# Altium schematic sheet Main.SchDoc(Main)
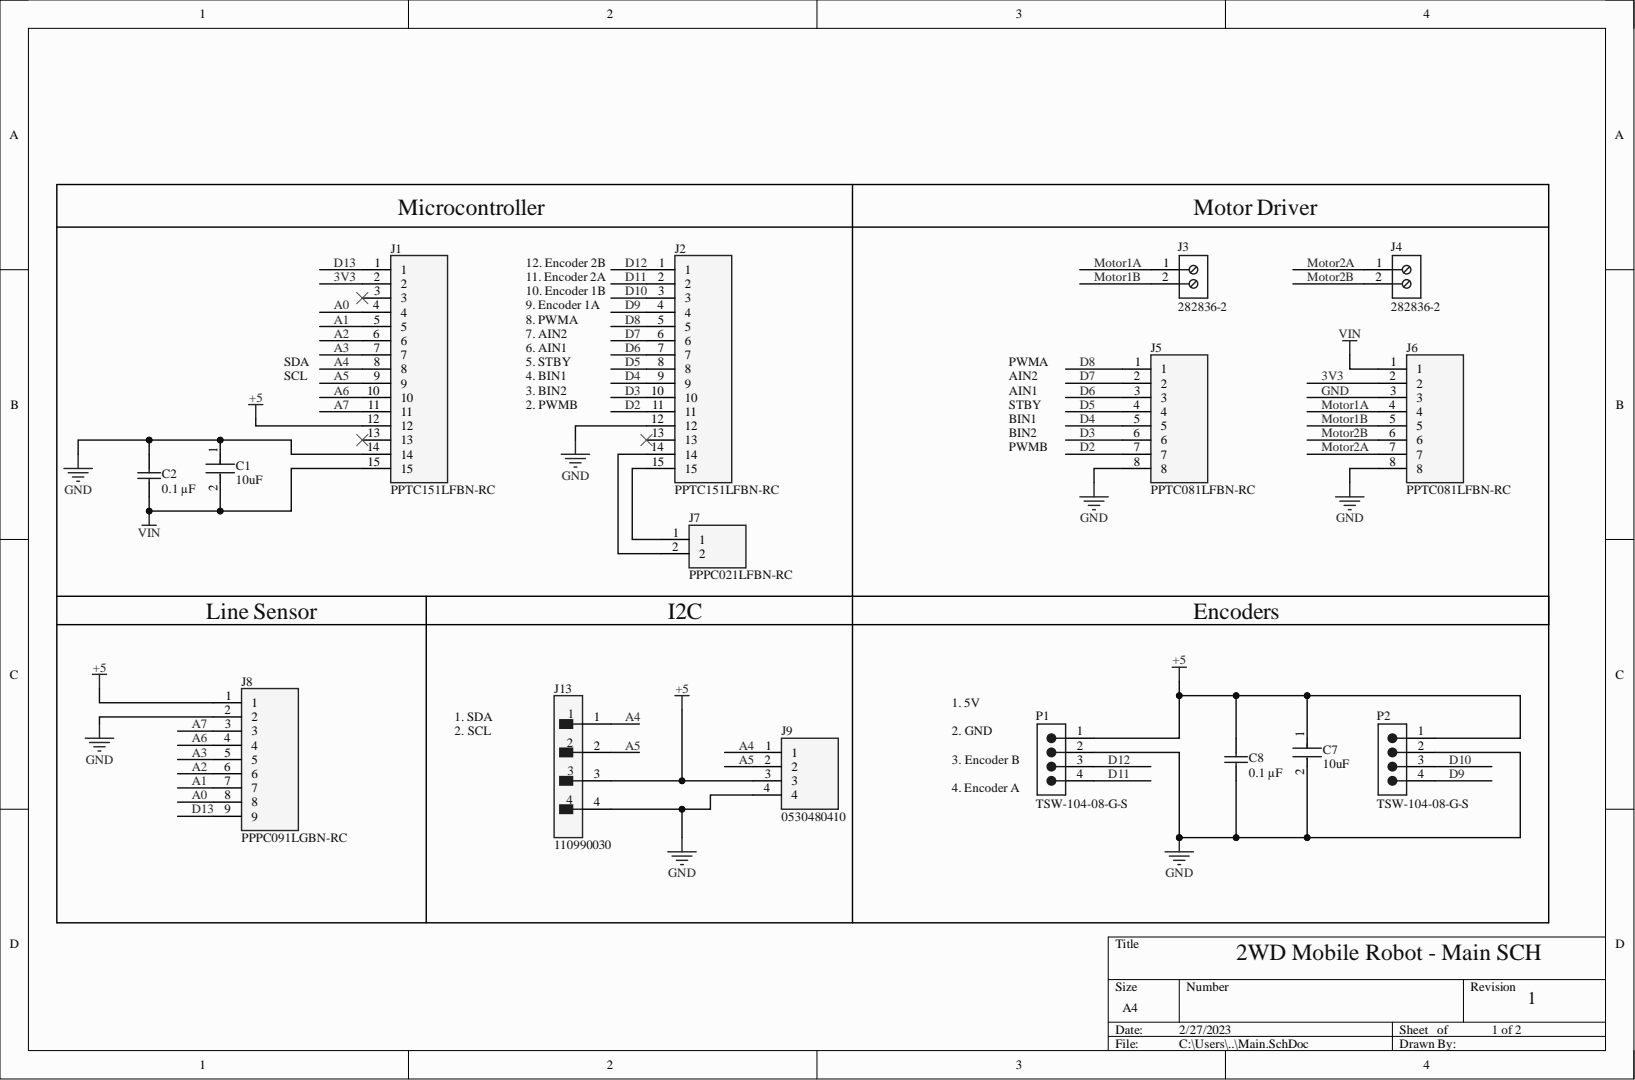
[diagram p0: Main.SchDoc]

## Parts
C1  10uF  [FP-GRM21B-0_2-e0_2_0_7-IPC_C]
C2  0.1 µF  [CAPC2013X140X50ML20T25]
C7  10uF  [FP-GRM21B-0_2-e0_2_0_7-IPC_C]
C8  0.1 µF  [CAPC2013X140X50ML20T25]
J1  PPTC151LFBN-RC  [RHDR15W85P0X254_1X15_3708X254X850P]
J2  PPTC151LFBN-RC  [RHDR15W85P0X254_1X15_3708X254X850P]
J3  282836-2  [TECO-282836-2_V]
J4  282836-2  [TECO-282836-2_V]
J5  PPTC081LFBN-RC  [RHDR8W80P0X254_1X8_2082X254X850P]
J6  PPTC081LFBN-RC  [RHDR8W80P0X254_1X8_2082X254X850P]
J7  PPPC021LFBN-RC  [RHDR2W80P0X254_1X2_558X254X850P]
J8  PPPC091LGBN-RC  [RHDRRA9W60P0X254_1X9_2336X850X274P]
J9  0530480410  [SHDRRA4W35P0X125_1X4_675X550X350P]
J13  110990030  [FP-110990030-MFG]
P1  TSW-104-08-G-S  [SMTC-TSW-104-08-X-S]
P2  TSW-104-08-G-S  [SMTC-TSW-104-08-X-S]

## Nets
+5: C7.1 C8.2 J1.12 J8.1 J9.3 J13.3 P1.1 P2.1
VIN: C1.2 C2.1 J1.15 J6.1
3V3: J1.2 J6.2
A0: J1.4 J8.8
A1: J1.5 J8.7
A2: J1.6 J8.6
A3: J1.7 J8.5
A4: J1.8 J9.1 J13.1
A5: J1.9 J9.2 J13.2
A6: J1.10 J8.4
A7: J1.11 J8.3
D2: J2.11 J5.7
D3: J2.10 J5.6
D4: J2.9 J5.5
D5: J2.8 J5.4
D6: J2.7 J5.3
D7: J2.6 J5.2
D8: J2.5 J5.1
D9: J2.4 P2.4
D10: J2.3 P2.3
D11: J2.2 P1.4
D12: J2.1 P1.3
D13: J1.1 J8.9
GND: C1.1 C2.2 C7.2 C8.1 J1.14 J2.12 J5.8 J6.3 J6.8 J8.2 J9.4 J13.4 P1.2 P2.2
Motor1A: J3.1 J6.4
Motor1B: J3.2 J6.5
Motor2A: J4.1 J6.7
Motor2B: J4.2 J6.6
NetJ2_14: J2.14 J7.2
NetJ2_15: J2.15 J7.1

Not connected: J1 3 13; J2 13

## Library data of the parts
C1 C7 = CMP-06035-048920-1: Chip Multilayer Ceramic Capacitors for General Purpose, 0805, 10uF, X5R, 15%, 10%, 35V; Manufacturer Product Number: GRM21BR6YA106KE43L; Capacitance: 10uF; Case Code (Imperial): 0805; Case Code (Metric): 2012; Case/Package: 0805; Component Kind: Standard; Dielectric: X5R; Height: 1.25mm; Lead Free: Lead Free; Length: 0.079inch; Library Name: Altium Content Vault; Max Operating Temperature: 85°C; Min Operating Temperature: -55°C; Mount: Surface Mount; Package Quantity: 3000; Packaging: Tape and Reel; REACH SVHC: No SVHC; Termination: SMD/SMT; Thickness: 0.057inch; Tolerance: 10%; Voltage Rating: 35V; Voltage Rating (DC): 35V; Weight: 0.000335oz; Width: 0.049inch
C2 C8 = CMP-2007-04651-1: 0.1 µF ±10% 35V Ceramic Capacitor X5R 0805 (2012 Metric); Manufacturer: AVX Interconnect / Elco; Part Number: 0805DD104KAT2A; Component Kind: Standard; Library Name: Altium Content Vault
J1 J2 = PPTC151LFBN-RC: Connector; Manufacturer_Name: Sullins; Component Kind: Standard; Datasheet Link: https://www.digikey.com/en/products/detail/sullins-connector-solutions/PPTC151LFBN-RC/810153; Height: 8.5mm; Manufacturer_Part_Number: PPTC151LFBN-RC
J3 J4 = CMP-1684-00017-1: Female Header, Pitch 5 mm, 1 x 2 Position, Height 10 mm, Tail Length 3.5 mm, Rohs, Bulk; Manufacturer: TE Connectivity; 3D Model: 282836-2.pdf; Clamp Material - Plating: Brass-NickelPlated; Current: 13.5A; Dynamic Catalog: BuchananSeries; Featured Product: EurostyleTerminalBlockConnectors; Mating Orientation: HorizontalwithBoard; Number of Levels: 1; Other Names: 5ULV-02B  A98076; Pitch: 0.197"(5.00mm); Positions Per Level: 2; Product Training Modules: Wire-to-BoardEurostyleTerminalBlocks; Screw Thread: M3; Torque - Screw: 0.68Nm(6Lb-In); Voltage: 300V; Wire Gauge: 16-30AWG; Wire Termination: Screw-RisingCageClamp; Category: Connectors,Interconnects; Color: Green; Component Kind: Standard; Contact Material - Plating: Brass-TinPlated; Datasheet URL: http://www.te.com.cn/commerce/DocumentDelivery/DDEController?Action=showdoc&DocId=Catalog+Section%7F1308389_EUROSTYLE_TERMINAL_BLOCKS%7F0607%7Fpdf%7FEnglish%7FENG_CS_1308389_EUROSTYLE_TERMINAL_BLOCKS_0607.pdf%7F282836-2; Family: TerminalBlocks-WiretoBoard; Features: Interlocking(Side); Housing Material: Polyamide(PA66),Nylon6/6; Library Name: Altium Content Vault; Manufacturer URL: http://www.te.com; Mounting Type: ThroughHole; Package Description: Female Header, Pitch 5 mm, 1 x 2 Position, Height 10 mm, Tail Length 3.5 mm; Package Reference: THD; Packaging: Bulk; RoHS Information: 282836-2StatementofCompliance; Screw Material - Plating: Brass-NickelPlated; Series: Buchanan; Standard Package: 300
J5 J6 = PPTC081LFBN-RC: Connector; Manufacturer_Name: Sullins; Component Kind: Standard; Datasheet Link: http://sullinscorp.com/catalogs/101_PAGE114-115_.100_FEMALE_HDR.pdf; Height: 8.5mm; Manufacturer_Part_Number: PPTC081LFBN-RC
J7 = PPPC021LFBN-RC: Connector; Manufacturer_Name: Sullins; Component Kind: Standard; Datasheet Link: http://www.sullinscorp.com/drawings/78_P(N)PxCxxxLFBN-RC,_10492-H.pdf; Height: 8.5mm; Manufacturer_Part_Number: PPPC021LFBN-RC
J8 = PPPC091LGBN-RC: Connector; Manufacturer_Name: Sullins; Component Kind: Standard; Datasheet Link: http://www.sullinscorp.com/drawings/78_P(N)PxCxx1LGBN-RC,_10493-G.pdf; Height: 2.74mm; Manufacturer_Part_Number: PPPC091LGBN-RC
J9 = 0530480410: Connector; Manufacturer_Name: Molex; Arrow Part Number: 0530480410; Arrow Price/Stock: https://www.arrow.com/en/products/0530480410/molex; Component Kind: Standard; Datasheet Link: http://www.molex.com/pdm_docs/sd/530480410_sd.pdf; Height: 3.5mm; Manufacturer_Part_Number: 0530480410; Mouser Part Number: N/A; Mouser Price/Stock: https://www.mouser.co.uk/ProductDetail/Molex/0530480410?qs=PBcRNPEHKsr6e1Q9gctk8A%3D%3D
J13 = CMP-139044-000001-1: GROVE 2MM 4PIN VERT CONN 10PCS; Component Kind: Standard; Library Name: Altium Content Vault; Series: -
P1 P2 = CMP-1024-00357-1: 0.025" SQ Post Header, Through-hole, Vertical, -55 to 125 degC, 2.54 mm Pitch, 4-Pin, Male, RoHS; Manufacturer: Samtec; Pitch: 2.54 mm; Component Kind: Standard; ComponentLink1Description: Manufacturer URL; ComponentLink1URL: http://www.samtec.com; ComponentLink2Description: Datasheet; ComponentLink2URL: http://www.samtec.com/documents/webfiles/pdf/TSW_TH.PDF; ComponentLink3Description: Package Specification; ComponentLink3URL: http://www.samtec.com/documents/webfiles/cpdf/TSW-XXX-XX-XXX-X-XX-XXX-MKT.pdf; DatasheetVersion: F-214; Library Name: Altium Content Vault; Mounting Technology: Through-hole; Orientation: Vertical; PackageDescription: .025[0.64] SQ. Post Terminal Strip Assembly; PackageReference: TSW-104-08-X-S; PackageVersion: DM, 8/1988; PartNumber: TSW-104-08-G-S; RoHS: True; Temperature Range: -55 to 125 degC
